# Altium schematic sheet decawave.SchDoc
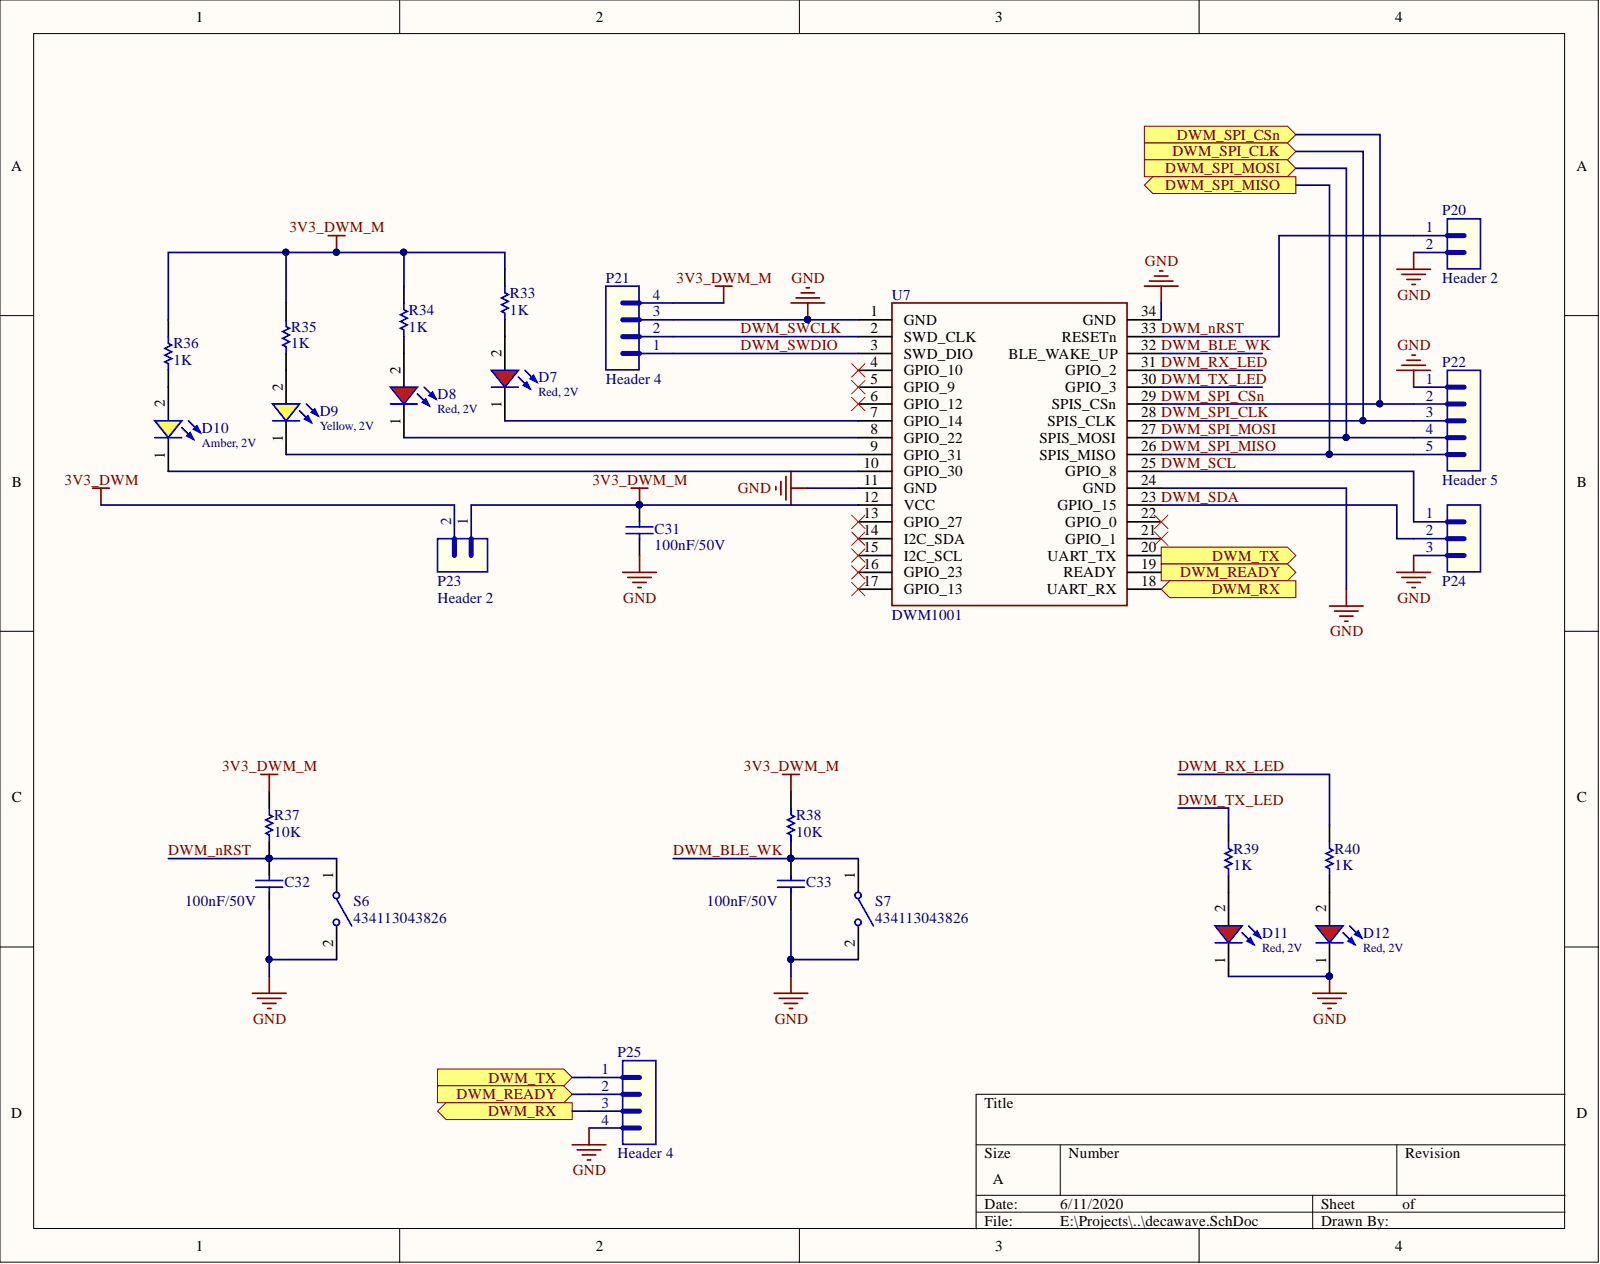
[diagram p0: decawave.SchDoc]

## Parts
C31  value 100nF/50V  [CAP-SM-0805]  (hidden comment: Cap-100nF-50V-0805)
C32  value 100nF/50V  [CAP-SM-0805]  (hidden comment: Cap-100nF-50V-0805)
C33  value 100nF/50V  [CAP-SM-0805]  (hidden comment: Cap-100nF-50V-0805)
D7  Red, 2V  Forward Voltage typ.: 2V  [WL-SMCW_0805]
D8  Red, 2V  Forward Voltage typ.: 2V  [WL-SMCW_0805]
D9  Yellow, 2V  Forward Voltage typ.: 2V  [WL-SMCW_0805]
D10  Amber, 2V  [WL-SMCW_1206]
D11  Red, 2V  [WL-SMCW_0805]
D12  Red, 2V  Forward Voltage typ.: 2V  [WL-SMCW_0805]
P20  Header 2  [JP2]
P21  Header 4  [JP4]
P22  Header 5  [JP5]
P23  Header 2  [JP2]
P24  Header 3  [JP3]
P25  Header 4  [JP4]
R33  value 1K  [RES-SM-0805]  (hidden comment: Res-1K-0805)
R34  value 1K  [RES-SM-0805]  (hidden comment: Res-1K-0805)
R35  value 1K  [RES-SM-0805]  (hidden comment: Res-1K-0805)
R36  value 1K  [RES-SM-0805]  (hidden comment: Res-1K-0805)
R37  value 10K  [RES-SM-0805]  (hidden comment: Res-10k-0805)
R38  value 10K  [RES-SM-0805]  (hidden comment: Res-10k-0805)
R39  value 1K  [RES-SM-0805]  (hidden comment: Res-1K-0805)
R40  value 1K  [RES-SM-0805]  (hidden comment: Res-1K-0805)
S6  434113043826  [434113043826]
S7  434113043826  [434113043826]
U7  DWM1001  [DWM1001]

## Ports
DWM_READY, DWM_RX, DWM_SPI_CLK, DWM_SPI_CSn, DWM_SPI_MISO, DWM_SPI_MOSI, DWM_TX

## Nets
3V3_DWM: P23.2
3V3_DWM_M: C31.2 P21.4 P23.1 R33.2 R34.2 R35.2 R36.2 R37.2 R38.2 U7.12(VCC)
GND: C31.1 C32.1 C33.1 D11.1 D12.1 P20.2 P21.3 P22.1 P24.3 P25.4 S6.2 S7.2 U7.1(GND) U7.11(GND) U7.24(GND) U7.34(GND)
DWM_BLE_WK: C33.2 R38.1 S7.1 U7.32(BLE_WAKE_UP)
DWM_nRST: C32.2 P20.1 R37.1 S6.1 U7.33(RESETn)
DWM_READY: P25.2 U7.19(READY) ; port DWM_READY
DWM_RX: P25.3 U7.18(UART_RX) ; port DWM_RX
DWM_RX_LED: R40.2 U7.31(GPIO_2)
DWM_SCL: P24.1 U7.25(GPIO_8)
DWM_SDA: P24.2 U7.23(GPIO_15)
DWM_SPI_CLK: P22.3 U7.28(SPIS_CLK) ; port DWM_SPI_CLK
DWM_SPI_CSn: P22.2 U7.29(SPIS_CSn) ; port DWM_SPI_CSn
DWM_SPI_MISO: P22.5 U7.26(SPIS_MISO) ; port DWM_SPI_MISO
DWM_SPI_MOSI: P22.4 U7.27(SPIS_MOSI) ; port DWM_SPI_MOSI
DWM_SWCLK: P21.2 U7.2(SWD_CLK)
DWM_SWDIO: P21.1 U7.3(SWD_DIO)
DWM_TX: P25.1 U7.20(UART_TX) ; port DWM_TX
DWM_TX_LED: R39.2 U7.30(GPIO_3)
NetD7_1: D7.1 U7.7(GPIO_14)
NetD7_2: D7.2 R33.1
NetD8_1: D8.1 U7.8(GPIO_22)
NetD8_2: D8.2 R34.1
NetD9_1: D9.1 U7.9(GPIO_31)
NetD9_2: D9.2 R35.1
NetD10_1: D10.1 U7.10(GPIO_30)
NetD10_2: D10.2 R36.1
NetD11_2: D11.2 R39.1
NetD12_2: D12.2 R40.1

Not connected: U7 4(GPIO_10) 5(GPIO_9) 6(GPIO_12) 13(GPIO_27) 14(I2C_SDA) 15(I2C_SCL) 16(GPIO_23) 17(GPIO_13) 21(GPIO_1) 22(GPIO_0)

## Library data of the parts
C31-C33 = Cap-100nF-50V-0805: CAP CER 0.1UF 50V Y5V 0805; Manufacturer: Samsung Electro-Mechanics; Manufacturer Part Number: CL21F104ZBCNNNC; Component Kind: Standard; Library Name: Capacitor.SchLib; Local Supplier: thegioiic; Local Supplier Link: https://thegioiic.com/products/tu-100nf-0-1uf-50v-0805; Supplier 1: Digikey; Supplier 1 Link: https://www.digikey.com/product-detail/en/samsung-electro-mechanics/CL21F104ZBCNNNC/1276-1007-1-ND/3889093; Supplier 1 Part Number: 1276-1007-1-ND
D7 D8 D11 D12 = 150080RS75000: WL-SMCW SMT Mono-color Chip LED Waterclear, size 0805, Red, 2V, 140deg; Chip Technology: AlInGaP; Dominant Wavelength typ.: 625nm; Luminous Intensity typ.: 150mcd; Peak Wavelength typ.: 630nm; Component Kind: Standard; ComponentLink1Description: Manufacturer; ComponentLink1URL: http://www.we-online.de; ComponentLink2Description: Datasheet; ComponentLink2URL: https://www.we-online.com/catalog/datasheet/150080RS75000.pdf; Emitting Color: Red; Library Name: 150080BS75000_Altium_WL-SMCW_rev20a.IntLib; Mounting Technology: SMT; Operating Temperature: -40 to +85degC; Packaging: Tape and Reel; Part Number: 150080RS75000; Published Date: December 2019; Size: 0805; Viewing Angle: 140deg; Publisher: Wurth Elektronik
  D11: Forward Voltage typ.: 2V
D9 = 150080YS75000: WL-SMCW SMT Mono-color Chip LED Waterclear, size 0805, Yellow, 2V, 140deg; Chip Technology: AlInGaP; Dominant Wavelength typ.: 590nm; Luminous Intensity typ.: 120mcd; Peak Wavelength typ.: 595nm; Component Kind: Standard; ComponentLink1Description: Manufacturer; ComponentLink1URL: http://www.we-online.de; ComponentLink2Description: Datasheet; ComponentLink2URL: https://www.we-online.com/catalog/datasheet/150080YS75000.pdf; Emitting Color: Yellow; Library Name: 150080BS75000_Altium_WL-SMCW_rev20a.IntLib; Mounting Technology: SMT; Operating Temperature: -40 to +85degC; Packaging: Tape and Reel; Part Number: 150080YS75000; Published Date: December 2019; Size: 0805; Viewing Angle: 140deg; Publisher: Wurth Elektronik
D10 = 150120AS75000: WL-SMCW SMT Mono-color Chip LED Waterclear, size 1206, Amber, 2V, 140deg; Chip Technology: AlInGaP; Dominant Wavelength typ.: 605nm; Luminous Intensity typ.: 140mcd; Peak Wavelength typ.: 610nm; Component Kind: Standard; ComponentLink1Description: Manufacturer; ComponentLink1URL: http://www.we-online.de; ComponentLink2Description: Datasheet; ComponentLink2URL: https://www.we-online.com/catalog/datasheet/150120AS75000.pdf; Emitting Color: Amber; Forward Voltage typ.: 2V; Library Name: 150080BS75000_Altium_WL-SMCW_rev20a.IntLib; Mounting Technology: SMT; Operating Temperature: -40 to +85degC; Packaging: Tape and Reel; Part Number: 150120AS75000; Published Date: December 2019; Size: 1206; Viewing Angle: 140deg; Publisher: Wurth Elektronik
P20 P23 = Header 2: Header, 2-Pin; Component Kind: Standard; LatestRevisionDate: 17-Jul-2002; LatestRevisionNote: Re-released for DXP Platform.; Library Name: Header-Connector.SchLib; Publisher: Altium Limited
P21 P25 = Header 4: Header, 4-Pin; Component Kind: Standard; LatestRevisionDate: 17-Jul-2002; LatestRevisionNote: Re-released for DXP Platform.; Library Name: Header-Connector.SchLib; Publisher: Altium Limited
P22 = Header 5: Header, 5-Pin; Component Kind: Standard; LatestRevisionDate: 17-Jul-2002; LatestRevisionNote: Re-released for DXP Platform.; Library Name: Header-Connector.SchLib; Publisher: Altium Limited
P24 = Header 3: Header, 3-Pin; Component Kind: Standard; LatestRevisionDate: 17-Jul-2002; LatestRevisionNote: Re-released for DXP Platform.; Library Name: Header-Connector.SchLib; Publisher: Altium Limited
R33-R36 R39 R40 = Res-1K-0805: RES 1K OHM 1% 1/4W 0805; Manufacturer: Stackpole Electronics Inc; Manufacturer Part Number: RNCP0805FTD1K00; Component Kind: Standard; Library Name: Resistor.SchLib; Local Supplier: thegioiic; Local Supplier Link: https://thegioiic.com/products/dien-tro-1k-ohm-0805-5; Supplier 1: Digikey; Supplier 1 Link: https://www.digikey.com/product-detail/en/stackpole-electronics-inc/RNCP0805FTD1K00/RNCP0805FTD1K00CT-ND/2240568; Supplier 1 Part Number: RNCP0805FTD1K00CT-ND
R37 R38 = Res-10k-0805: RES 10K OHM 1% 1/4W 0805; Manufacturer: Stackpole Electronics Inc; Manufacturer Part Number: RNCP0805FTD10K0; Component Kind: Standard; Library Name: Resistor.SchLib; Local Supplier: thegioiic; Local Supplier Link: https://thegioiic.com/products/dien-tro-10k-ohm-0805-5; Supplier 1: Digikey; Supplier 1 Link: https://www.digikey.com/product-detail/en/stackpole-electronics-inc/RNCP0805FTD10K0/RNCP0805FTD10K0CT-ND/2240601; Supplier 1 Part Number: RNCP0805FTD10K0CT-ND
S6 S7 = 434113043826: WS-TASV J-Bend SMT Tact Switch 6x3.5mm, height 4.3mm, 260gf; Rated Current: 50mA; Rated Voltage: 12VDC; Washable: No; Component Kind: Standard; ComponentLink1Description: Manufacturer; ComponentLink1URL: http://www.we-online.de; ComponentLink2Description: Datasheet; ComponentLink2URL: https://katalog.we-online.com/em/datasheet/434113043826.pdf; Contact Material: Stainless Steel; Contact Plating: Silver; Height: 4.3mm; Library Name: 434111043826_Altium_WS-TASV_rev20a.IntLib; Mounting Technology: SMT; Operating Temperature: -40 to +85degC; Operation Force: 260gf; Packaging: Tape and Reel; Part Number: 434113043826; Published Date: September 2019; Terminal Material: Copper Alloy; Terminal Plating: Silver; Publisher: Wurth Elektronik
U7 = DWM1001: Component Kind: Standard; Library Name: PIFWRobot.SchLib
